# Altium schematic sheet SD JTAG.SchDoc("SD JTAG")
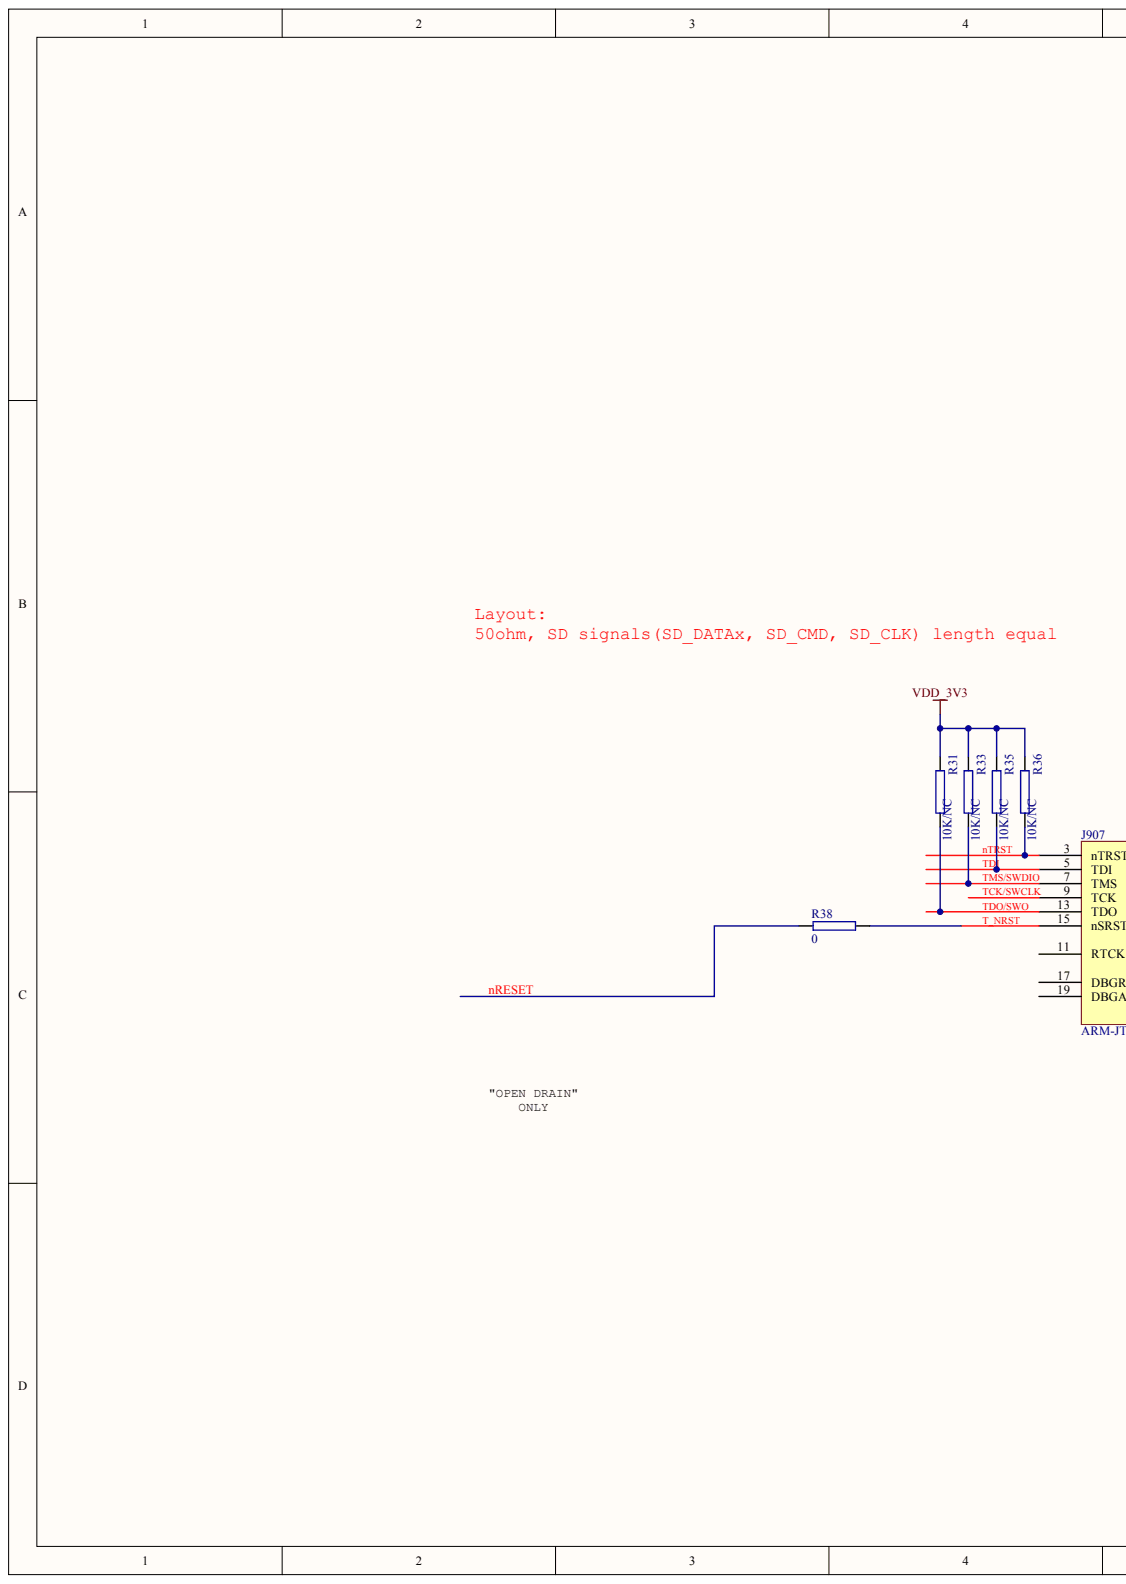
[diagram p0: SD JTAG.SchDoc, left]
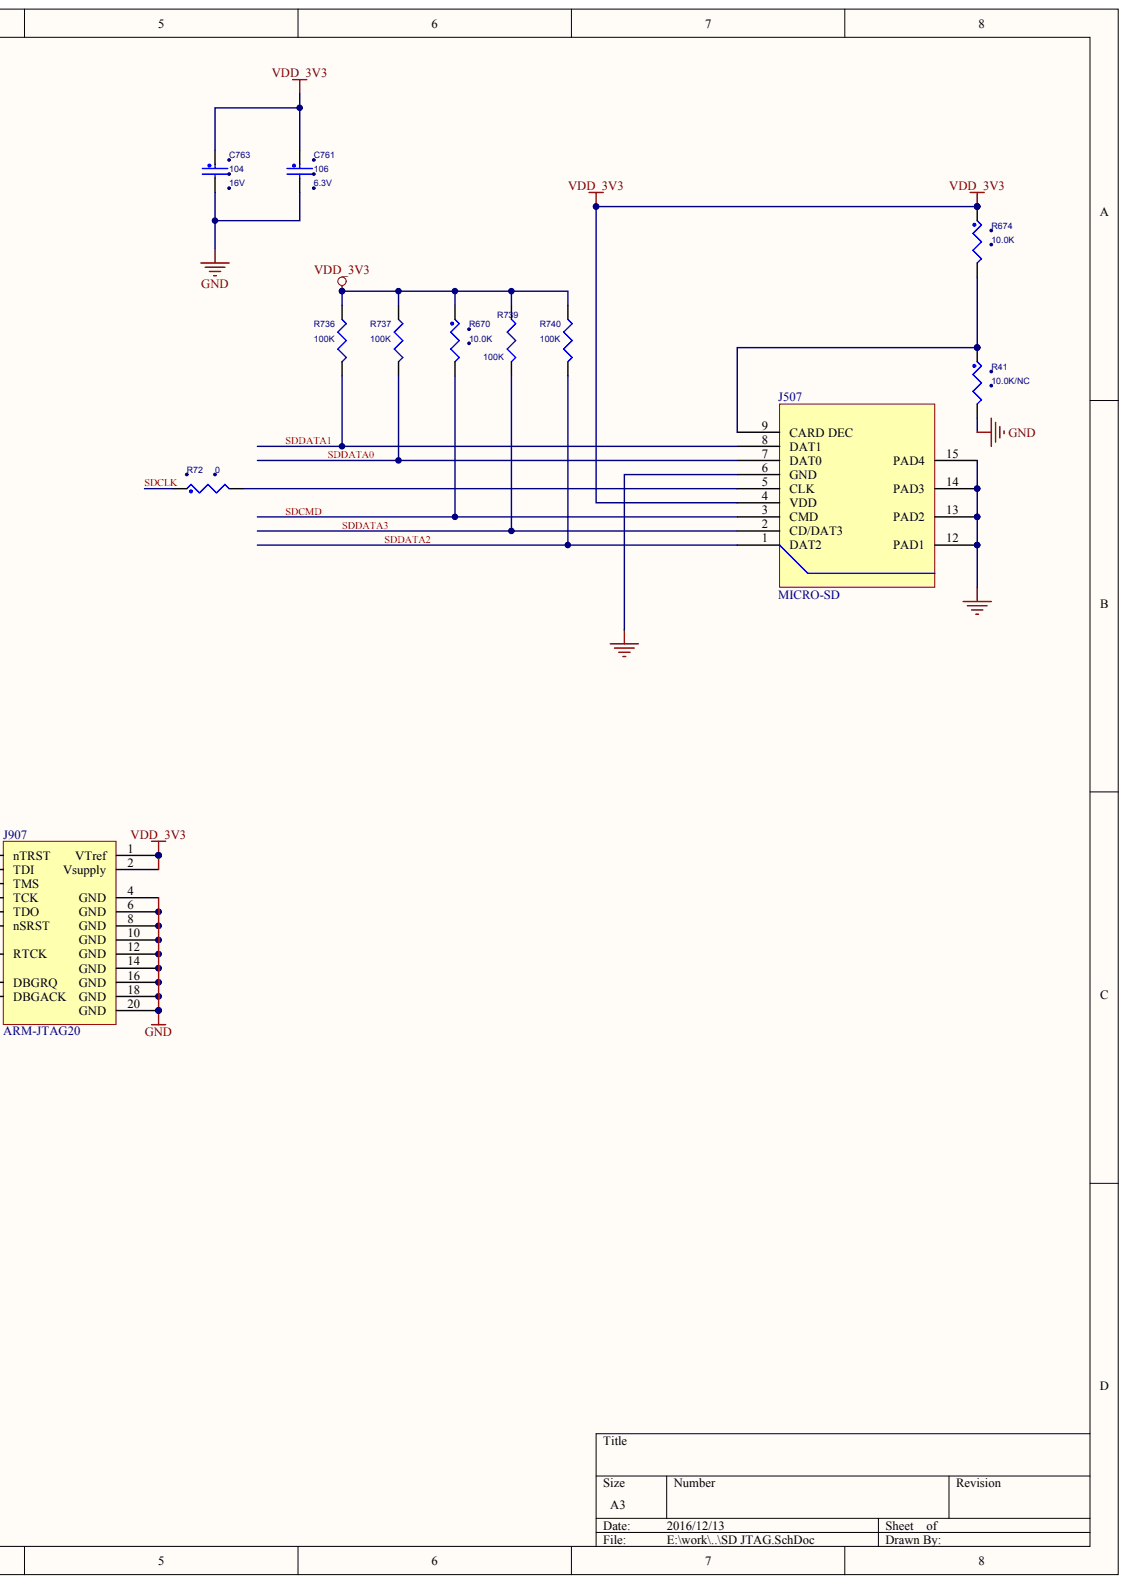
[diagram p1: SD JTAG.SchDoc, right]

## Parts
C761  106  WVDC: 6.3V
C763  104  WVDC: 16V
J507  MICRO-SD
J907  ARM-JTAG20
R31  10K/NC
R33  10K/NC
R35  10K/NC
R36  10K/NC
R38  0
R41  10.0K/NC
R72  0
R670  10.0K
R674  10.0K
R736  100K
R737  100K
R739  100K
R740  100K

## Nets
GND: C761.2 C763.2 J507.6(GND) J507.12(PAD1) J507.13(PAD2) J507.14(PAD3) J507.15(PAD4) J907.4(GND) J907.6(GND) J907.8(GND) J907.10(GND) J907.12(GND) J907.14(GND) J907.16(GND) J907.18(GND) J907.20(GND) R41.2
VDD_3V3: C761.1 C763.1 J507.4(VDD) J907.1(VTref) J907.2(Vsupply) R31.1 R33.1 R35.1 R36.1 R670.1 R674.1 R736.1 R737.1 R739.1 R740.1
NRESET: R38.1
NTRST: J907.3(nTRST) R36.2
SDCLK: R72.1
SDCMD: J507.3(CMD) R670.2
SDDATA0: J507.7(DAT0) R737.2
SDDATA1: J507.8(DAT1) R736.2
SDDATA2: J507.1(DAT2) R740.2
SDDATA3: J507.2(CD/DAT3) R739.2
T_NRST: J907.15(nSRST) R38.2
TCK/SWCLK: J907.9(TCK)
TDI: J907.5(TDI) R35.2
TDO/SWO: J907.13(TDO) R31.2
TMS/SWDIO: J907.7(TMS) R33.2
NetJ507_5: J507.5(CLK) R72.2
NetJ507_9: J507.9(CARD_DEC) R41.1 R674.2

Not connected: J907 11(RTCK) 17(DBGRQ) 19(DBGACK)

## Library data of the parts
C761 = (no symbol name): CLASS: DISCRETE; AGILE_PN: 150-77832; Tolerance: 10%; MFG_NAME01: TDK; MFG_PN01: C1608X5R0J106KT; CAD_APPROVED: Yes; FPLIST: CC0603_OV,CC0603,0603_CC; SIGNAL_MODEL: DEFAULT_CAPACITOR_1e+07pF_2_1; ROHS: Yes; HEIGHT: 1.0MM; VOLTAGE: 6.3V
C763 = (no symbol name): CLASS: DISCRETE; FPLIST: CC0402_25,CC0402_HD,0402_CC; CAD_APPROVED: Yes; MFG_PN01: C0402C104K4RAC; MFG_NAME01: KEMET; Tolerance: 10%; AGILE_PN: 150-75182; SIGNAL_MODEL: DEFAULT_CAPACITOR_100000pF_2_1; HEIGHT: 0.635MM; ROHS: Yes; VOLTAGE: 16V
R41 R72 R670 R674 = (no symbol name): CLASS: DISCRETE; FPLIST: RC0402_25,RC0402_HD,0402_CC; CAD_APPROVED: Yes; MFG_PN01: CRCW040210K0FKED; MFG_NAME01: VISHAY INTERTECHNOLOGY; Tolerance: 1%; AGILE_PN: 470-75416; SIGNAL_MODEL: DEFAULT_RESISTOR_10000OHM_2_1; ROHS: Yes
R736 R737 R739 R740 = (no symbol name): Right: 20; Bottom: 30
